# Altium schematic sheet CW308T_S6LX9_FPGAMisc.SchDoc
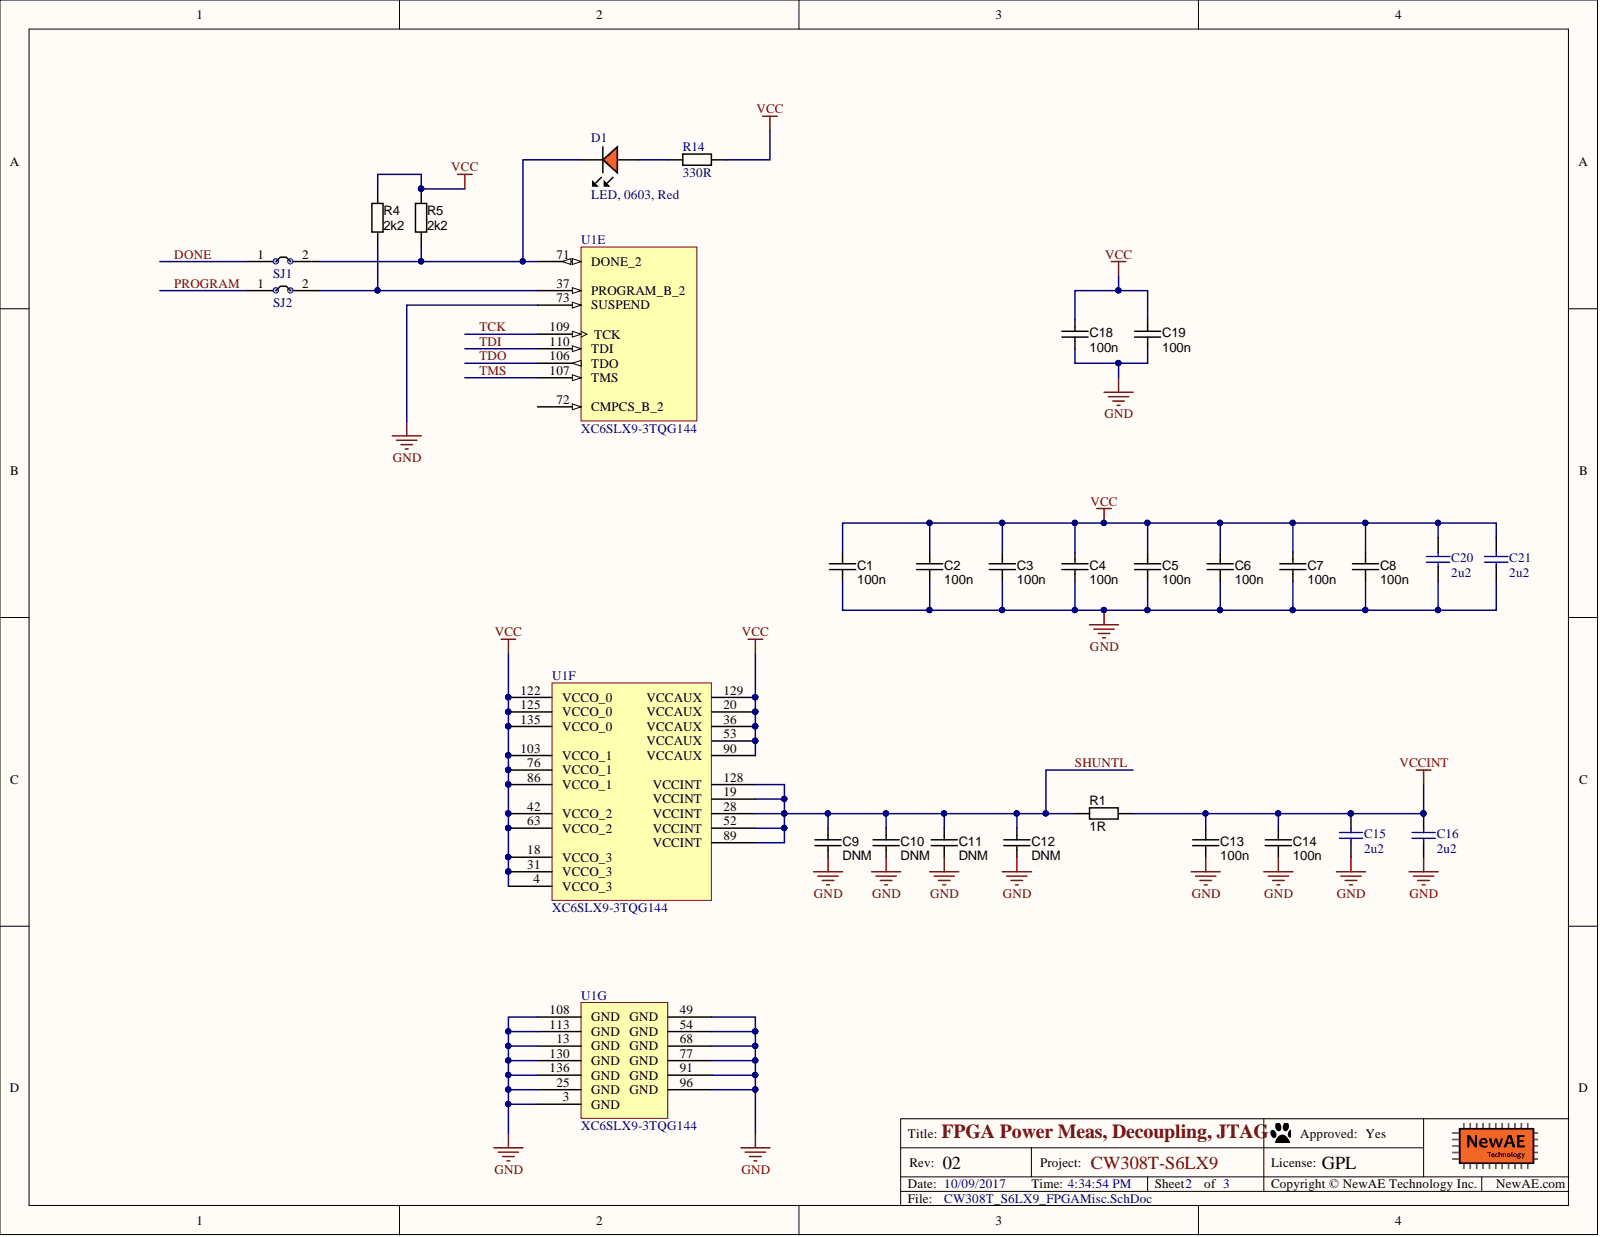
[diagram p0: CW308T_S6LX9_FPGAMisc.SchDoc]

## Parts
C1  value 100n  [CAPC1608X90N]  (hidden comment: approved sub OK)
C2  value 100n  [CAPC1608X90N]  (hidden comment: approved sub OK)
C3  value 100n  [CAPC1608X90N]  (hidden comment: approved sub OK)
C4  value 100n  [CAPC1608X90N]  (hidden comment: approved sub OK)
C5  value 100n  [CAPC1608X90N]  (hidden comment: approved sub OK)
C6  value 100n  [CAPC1608X90N]  (hidden comment: approved sub OK)
C7  value 100n  [CAPC1608X90N]  (hidden comment: approved sub OK)
C8  value 100n  [CAPC1608X90N]  (hidden comment: approved sub OK)
C9  value DNM  [CAPC1608X90N]  (hidden comment: approved sub OK)
C10  value DNM  [CAPC1608X90N]  (hidden comment: approved sub OK)
C11  value DNM  [CAPC1608X90N]  (hidden comment: approved sub OK)
C12  value DNM  [CAPC1608X90N]  (hidden comment: approved sub OK)
C13  value 100n  [CAPC1608X90N]  (hidden comment: approved sub OK)
C14  value 100n  [CAPC1608X90N]  (hidden comment: approved sub OK)
C15  2u2  [0603]
C16  2u2  [0603]
C18  value 100n  [CAPC1608X90N]  (hidden comment: approved sub OK)
C19  value 100n  [CAPC1608X90N]  (hidden comment: approved sub OK)
C20  2u2  [0603]
C21  2u2  [0603]
D1  LED, 0603, Red  [LED-0603-RED]
R1  value 1R  [RESC1608X55N]  (hidden comment: Thick Film)
R4  value 2k2  [RESC1608X55N]  (hidden comment: Thick Film)
R5  value 2k2  [RESC1608X55N]  (hidden comment: Thick Film)
R14  330R  [0603]
SJ1  NC  [SJ_2S_NC]  (value not drawn)  (no BOM)
SJ2  NC  [SJ_2S_NC]  (value not drawn)  (no BOM)
U1  XC6SLX9-3TQG144  [TQG144_L]  (parts E,F,G)

## Nets
GND: C1.2 C2.2 C3.2 C4.2 C5.2 C6.2 C7.2 C8.2 C9.2 C10.2 C11.2 C12.2 C13.2 C14.2 C15.2 C16.2 C18.2 C19.2 C20.2 C21.2 U1.3(GND) U1.13(GND) U1.25(GND) U1.49(GND) U1.54(GND) U1.68(GND) U1.73(SUSPEND) U1.77(GND) U1.91(GND) U1.96(GND) U1.108(GND) U1.113(GND) U1.130(GND) U1.136(GND)
VCC: C1.1 C2.1 C3.1 C4.1 C5.1 C6.1 C7.1 C8.1 C18.1 C19.1 C20.1 C21.1 R4.1 R5.1 R14.2 U1.4(VCCO_3) U1.18(VCCO_3) U1.20(VCCAUX) U1.31(VCCO_3) U1.36(VCCAUX) U1.42(VCCO_2) U1.53(VCCAUX) U1.63(VCCO_2) U1.76(VCCO_1) U1.86(VCCO_1) U1.90(VCCAUX) U1.103(VCCO_1) U1.122(VCCO_0) U1.125(VCCO_0) U1.129(VCCAUX) U1.135(VCCO_0)
VCCINT: C13.1 C14.1 C15.1 C16.1 R1.2
DONE: SJ1.1
PROGRAM: SJ2.1
SHUNTL: C9.1 C10.1 C11.1 C12.1 R1.1 U1.19(VCCINT) U1.28(VCCINT) U1.52(VCCINT) U1.89(VCCINT) U1.128(VCCINT)
TCK: U1.109(TCK)
TDI: U1.110(TDI)
TDO: U1.106(TDO)
TMS: U1.107(TMS)
NetD1_A: D1.A R14.1
NetD1_K: D1.K R5.2 SJ1.2 U1.71(DONE_2)
NetR4_2: R4.2 SJ2.2 U1.37(PROGRAM_B_2)

Not connected: U1 72(CMPCS_B_2)

## Library data of the parts
C1-C14 C18 C19 = CAPC_100n_0603_X7R_50V_10%: Capacitor SMD Cer 100nF 10% 50V X7R 0603; Manufacturer 1: AVX Corporation; Manufacturer Part Number 1: 06035C104KAT2A; Material: ceramic; Ratings: 50V; X7R; SCDType: spec; Component Kind: Standard; ComponentLink1Description: http://www.avx.com/docs/Catalogs/cx7r.pdf; ComponentLink1URL: http://www.avx.com/docs/Catalogs/cx7r.pdf; ComponentLink2Description: http://product.tdk.com/capacitor/mlcc/en/documents/mlcc_commercial_general_en.pdf; ComponentLink2URL: http://product.tdk.com/capacitor/mlcc/en/documents/mlcc_commercial_general_en.pdf; Library Name: PBL - Capacitors.SCHLIB; Manufacturer 2: TDK Corporation; Manufacturer Part Number 2: C1608X7R1H104K; Package / Case: 0603 (1608 Metric); Supplier 1: Digi-Key; Supplier 2: Digi-Key; Supplier Part Number 1: 478-5052-1-ND; Supplier Part Number 2: 445-1314-1-ND; Tolerance: ±10%
C15 C16 C20 C21 = CCHIP-2u2-0603: 2u2, 0603; Case-Metric: 1608; Component Group: Capacitor; Database Table Name: main; Case-EIA: 0603; Component Kind: Standard; Component Type: Standard; Library Name: NewAE_AltiumLib.DBLib; Mounting Technology: SMT; Part Number: CCHIP-2u2-0603; Pin Count: 2; Value: 2u2
D1 = LEDCHIP_0603_RED: Chip LED, Red; Component Group: Optical; Database Table Name: main; Component Kind: Standard; Component Type: Standard; Library Name: NewAE_AltiumLib.DBLib; Mounting Technology: SMT; Part Number: LEDCHIP_0603_RED; Pin Count: 2
R1 = RES_3R3_0603_5%: Resistor SMD chip 3.3 Ohm 0.1W 5% 0603; Manufacturer 1: Yageo; Manufacturer Part Number 1: RC0603JR-073R3L; Ratings: 1/10 W; SCDType: spec; Component Kind: Standard; ComponentLink1Description: http://www.yageo.com/documents/recent/PYu-RC0603_51_RoHS_L_4.pdf; ComponentLink1URL: http://www.yageo.com/documents/recent/PYu-RC0603_51_RoHS_L_4.pdf; Library Name: PBL - Resistors.SCHLIB; Package / Case: 0603 (1608 metric); Supplier 1: Digi-Key; Supplier Part Number 1: 311-3.3GRCT-ND; Tolerance: ±5%
R4 R5 = RES_1K_0603_5%: Resistor SMD chip 1k Ohm 0.1W 5% 0603; Manufacturer 1: Yageo; Manufacturer Part Number 1: RC0603JR-071KL; Ratings: 1/10 W; SCDType: spec; Component Kind: Standard; ComponentLink1Description: http://www.yageo.com/documents/recent/PYu-RC0603_51_RoHS_L_4.pdf; ComponentLink1URL: http://www.yageo.com/documents/recent/PYu-RC0603_51_RoHS_L_4.pdf; ComponentLink2Description: http://www.vishay.com/doc?20008; ComponentLink2URL: http://www.vishay.com/doc?20008; Library Name: PBL - Resistors.SCHLIB; Manufacturer 2: Vishay Dale; Manufacturer Part Number 2: CRCW06031K00JNEA; Material: Thick Film; Package / Case: 0603 (1608 metric); Supplier 1: Digi-Key; Supplier 2: Digi-Key; Supplier Part Number 1: 311-1.0KGRCT-ND; Supplier Part Number 2: 541-1.0KGCT-ND; Tolerance: ±5%
R14 = RCHIP-330R-0603: 330R res, 0603, 1%, 1/10W; Manufacture 1: Yageo; Manufacture Part Number 1: RC0603FR-07330RL; Case-Metric: 1608; Component Group: Resistor; Database Table Name: main; Rated Power: 0.1W; Rated Voltage: 75VDC; Case-EIA: 0603; Component Kind: Standard; Component Type: Standard; Library Name: NewAE_AltiumLib.DBLib; Mounting Technology: SMT; Part Number: RCHIP-330R-0603; Pin Count: 2; Supplier 1: Digi-Key; Supplier Part Number 1: 311-330HRCT-ND; Temperature Range: -55C to 155C; Tolerance: 1%; Value: 330R
SJ1 SJ2 = SJ_2S: Solder Jumper, NC; Library Name: NewAE_AltiumLib.SchLib
U1 = CMP-0068-00084-1: Spartan-6 LX 1.0V FPGA, 102 User I/Os, 144-Pin TQFP (0.5mm Pitch), Low Power Version, Commercial Grade, Pb-Free; Code_JEDEC: MS-026-BFB; Component Kind: Standard; DatasheetVersion: ver1.6, Nov-2010; Library Name: Altium Content Vault; PackageDescription: 144-Pin Thin Quad Flat Pack (TQG144) - Pb-Free; PackageReference: TQG144; PackageVersion: ver1.2, Jun-2004; PartId: 1
